# Altium schematic sheet 00_POWER.SchDoc(00_POWER)
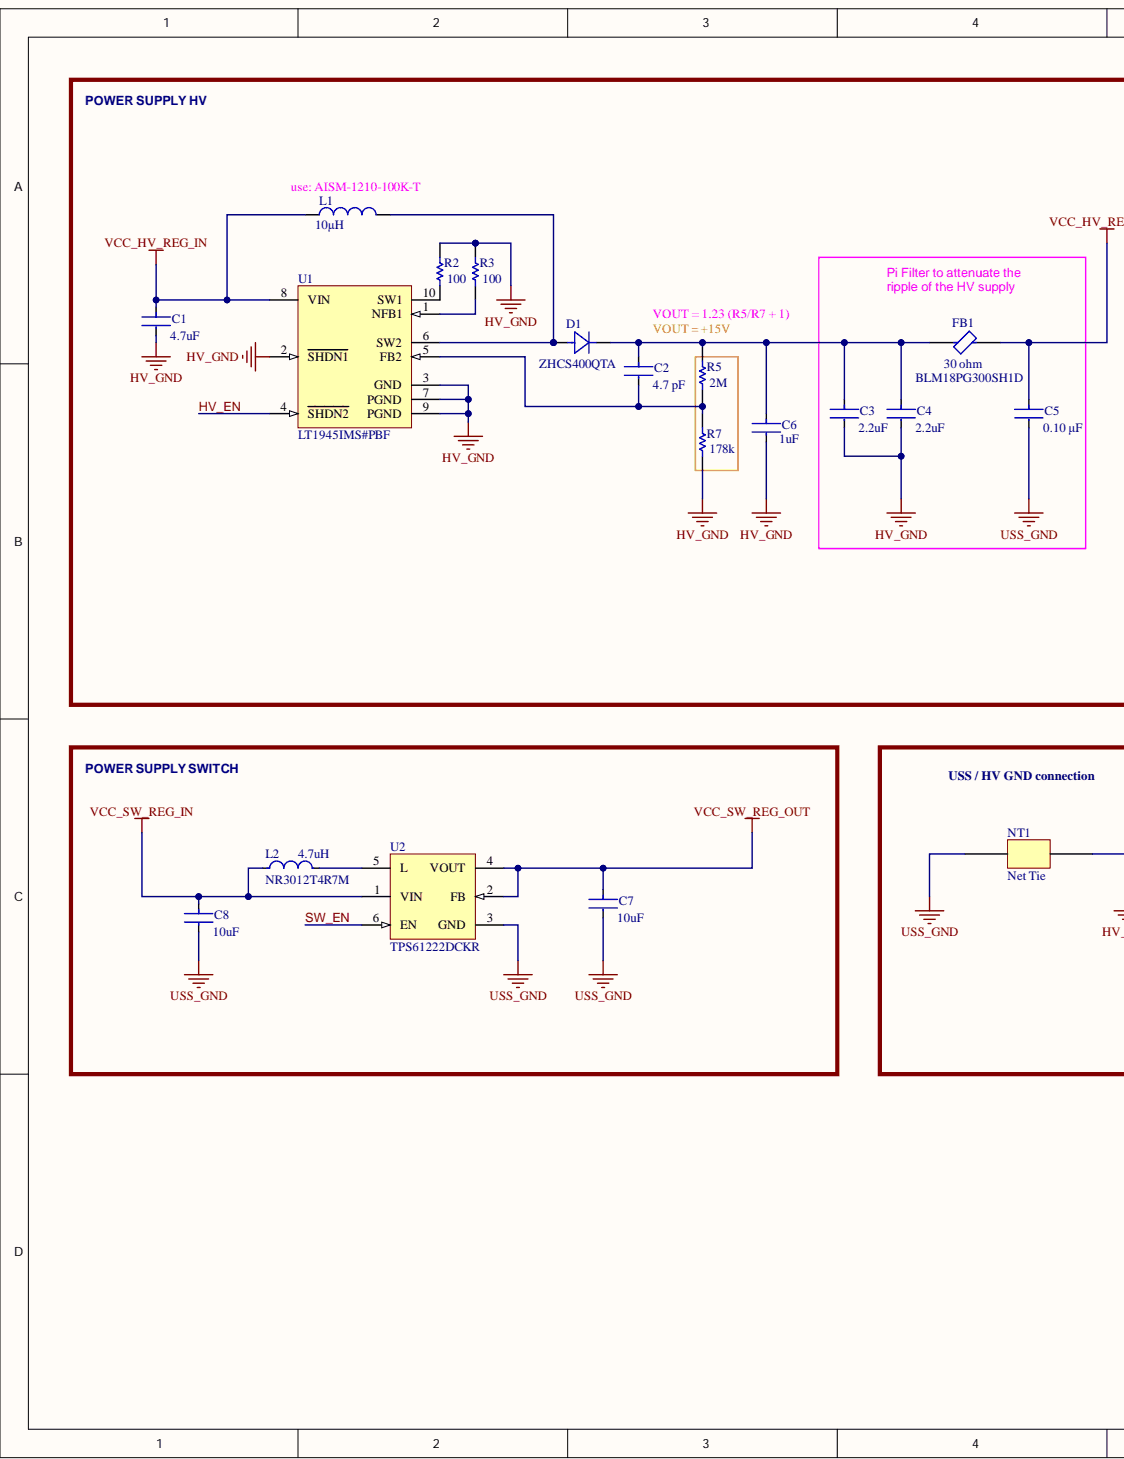
[diagram p0: 00_POWER.SchDoc, left]
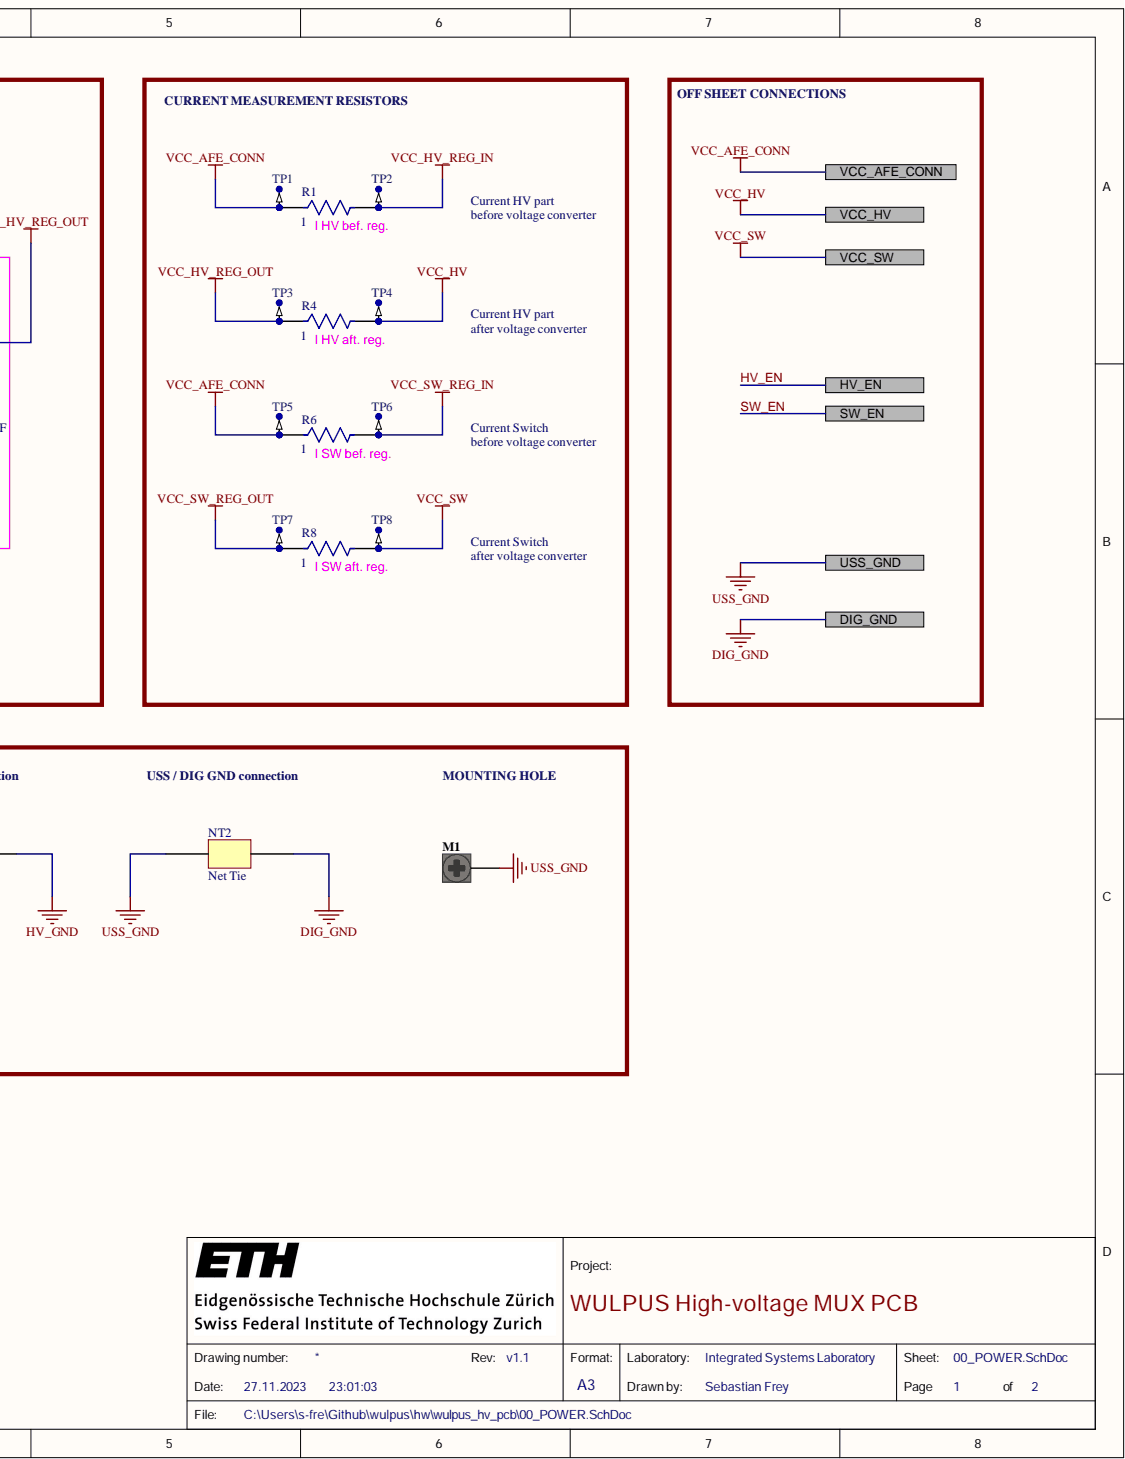
[diagram p1: 00_POWER.SchDoc, right]

## Parts
C1  Capacitance: 4.7uF  [FP-0603-L_1_6_0_15-W_0_81-MFG]  (hidden comment: 06036D475KAT2A)
C2  value 4.7 pF  Capacitance: 4.7 pF  [FP-C0402-BB-MFG]  (hidden comment: C0402C479C5GACTU)
C3  Capacitance: 2.2uF  [FP-GRM188-0_2-IPC_C]  (hidden comment: GRM188R61H225KE11D)
C4  Capacitance: 2.2uF  [FP-GRM188-0_2-IPC_C]  (hidden comment: GRM188R61H225KE11D)
C5  value 0.10 μF  Capacitance: 0.10 μF  [FP-C0603C-CF-MFG]  (hidden comment: C0603C104M5RACTU)
C6  Capacitance: 1uF  [FP-1206-L_3_2_0_15-W_1_6-IPC_B]  (hidden comment: GMK316BJ105KLHT)
C7  Capacitance: 10uF  [FP-GRM188-0_1-e0_2_0_55-IPC_A]  (hidden comment: GRM188R60J106ME47D)
C8  Capacitance: 10uF  [FP-GRM188-0_1-e0_2_0_55-IPC_A]  (hidden comment: GRM188R60J106ME47D)
D1  ZHCS400QTA  [DIODE_SOD323_DIO]
FB1  BLM18PG300SH1D  Impedance: 30 ohm  [FP-BLM18-0_15-t0_8-IPC_A]
L1  Inductance: 10µH  [TDK-B82422A1103K100_V]  (hidden comment: B82422A1103K100)
L2  NR3012T4R7M  Inductance: 4.7uH  [FP-NR3012-MFG]
M1  Mounting Hole for AFE PCB  [Hole M2]  (value not drawn)  (no BOM)
NT1  Net Tie  [Net_Tie_Top_Bottom]
NT2  Net Tie  [Net_Tie_Top_Bottom]
R1  CSR0402FK1R00  [FP-CSR0402-MFG]  (value not drawn)
R2  ERJ-2GEJ101X  [RESC1005X40X25NL05T05]  (value not drawn)
R3  ERJ-2GEJ101X  [RESC1005X40X25NL05T05]  (value not drawn)
R4  CSR0402FK1R00  [FP-CSR0402-MFG]  (value not drawn)
R5  Resistance: 2M  [RESC1005X40X25NL05T10]  (hidden comment: RC0402FR-072ML)
R6  CSR0402FK1R00  [FP-CSR0402-MFG]  (value not drawn)
R7  Resistance: 178k  [RESC1005X40X25ML05T05]  (hidden comment: ERJ-2RKF1783X)
R8  CSR0402FK1R00  [FP-CSR0402-MFG]  (value not drawn)
TP1  TP-SM-C-100  [TP-SM-C-100]  (value not drawn)  (no BOM)
TP2  TP-SM-C-100  [TP-SM-C-100]  (value not drawn)  (no BOM)
TP3  TP-SM-C-100  [TP-SM-C-100]  (value not drawn)  (no BOM)
TP4  TP-SM-C-100  [TP-SM-C-100]  (value not drawn)  (no BOM)
TP5  TP-SM-C-100  [TP-SM-C-100]  (value not drawn)  (no BOM)
TP6  TP-SM-C-100  [TP-SM-C-100]  (value not drawn)  (no BOM)
TP7  TP-SM-C-100  [TP-SM-C-100]  (value not drawn)  (no BOM)
TP8  TP-SM-C-100  [TP-SM-C-100]  (value not drawn)  (no BOM)
U1  LT1945IMS#PBF  [LT-MS-10_L]
U2  TPS61222DCKR  [DCK0006A_L]

## Ports
DIG_GND, HV_EN, SW_EN, USS_GND, VCC_AFE_CONN, VCC_HV, VCC_SW

## Nets
HV_GND: C1.2 C3.2 C4.2 C6.2 NT1.2 R2.2 R3.2 R7.1 U1.2(SHDN1) U1.3(GND) U1.7(PGND) U1.9(PGND)
VCC_HV_REG_IN: C1.1 L1.1 R1.2 TP2.1 U1.8(VIN)
VCC_HV_REG_OUT: C5.1 FB1.2 R4.1 TP3.1
VCC_SW_REG_IN: C8.1 L2.1 R6.2 TP6.1 U2.1(VIN)
VCC_SW_REG_OUT: C7.1 R8.1 TP7.1 U2.2(FB) U2.4(VOUT)
DIG_GND: NT2.2 ; port DIG_GND
HV_EN: U1.4(SHDN2) ; port HV_EN
SW_EN: U2.6(EN) ; port SW_EN
USS_GND: C5.2 C7.2 C8.2 M1.1 NT1.1 NT2.1 U2.3(GND) ; port USS_GND
VCC_AFE_CONN: R1.1 R6.1 TP1.1 TP5.1 ; port VCC_AFE_CONN
VCC_HV: R4.2 TP4.1 ; port VCC_HV
VCC_SW: R8.2 TP8.1 ; port VCC_SW
NetC2_1: C2.1 C3.1 C4.1 C6.1 D1.1 FB1.1 R5.2
NetC2_2: C2.2 R5.1 R7.2 U1.5(FB2)
NetD1_2: D1.2 L1.2 U1.6(SW2)
NetL2_2: L2.2 U2.5(L)
NetR2_1: R2.1 U1.10(SW1)
NetR3_1: R3.1 U1.1(NFB1)

## Library data of the parts
C1 = CMP-2006-04481-2: General Purpose Ceramic Capacitor, 0603, 4.7uF, 10%, X5R, 15%, 6.3V; Tolerance: 20%; Case Code (Imperial): 0603; Case Code (Metric): 1608; Case/Package: 0603; Component Kind: Standard; Construction: Flat; Contact Plating: Tin; Depth: 0.81mm; Dielectric: X5R; Dielectric Material: Ceramic; Height: 0.9mm; Lead Free: Lead Free; Length: 1.6mm; Library Name: Altium Content Vault; Max Operating Temperature: 85°C; Min Operating Temperature: -55°C; Mount: Surface Mount; Number of Pins: 2; Package Quantity: 1; Packaging: Tape and Reel; Radiation Hardening: No; REACH SVHC: No SVHC; RoHS Compliant: Yes; Series: -; Termination: SMD/SMT; Thickness: 0.035inch; Voltage: 6.3V; Voltage Rating: 6.3V; Voltage Rating (DC): 6.3V; Width: 0.032inch
C2 = CMP-2008-03310-2: CAP CER 4.7PF 50V NP0 0402; Manufacturer: Kemet; Material: Ceramic; Temperature Characteristic: C0G; Temperature Coefficient: 15%; Tolerance: 0.25 pF; Automotive: No; Category: Cap; Component Kind: Standard; ComponentLink2Description: Datasheet; ComponentLink2URL: https://content.kemet.com/datasheets/KEM_C1002_X7R_SMD.pdf; Device Class L1: Passive Components; Device Class L2: Capacitors; Device Class L3: Ceramic Capacitors; Digikey Description: CAP CER 4.7PF 50V NP0 0402; Height: 0.55mm; Ipc Land Pattern Name: CAPC10050X50; Lead Free: Yes; Library Name: Altium Content Vault; Manufacturer Part Number: C0402C479C5GACTU; Mouser Description: Multilayer Ceramic Capacitors MLCC - SMD/SMT 50volts 4.7pF C0G .25pF; Octopart: https://octopart.com/c0402c479c5gactu-kemet-9144199; Package: C0402-BB; RoHS: TRUE; Temperature: -55°C to +125°C; Temperature Range High: +125°C; Temperature Range Low: -55°C; Voltage Rating: 50 V
C3 C4 = CMP-06035-042125-1: Chip Multilayer Ceramic Capacitors for General Purpose, 0603, 2.2uF, X5R, 15%, 10%, 50V; Case Code (Imperial): 0603; Case Code (Metric): 1608; Case/Package: 0603; Component Kind: Standard; Dielectric: X5R; Height: 0.8mm; Length: 0.063inch; Library Name: Altium Content Vault; Material: Ceramic; Max Operating Temperature: 85°C; Min Operating Temperature: -55°C; Mount: Surface Mount; Packaging: Cut Tape; REACH SVHC: No SVHC; Thickness: 0.039inch; Tolerance: 10%; Voltage Rating: 50V; Voltage Rating (DC): 50V; Width: 0.031inch
C5 = CMP-2006-03068-2: CAP CER 0.1UF 50V 20% X7R 0603; Manufacturer: KEMET; Automotive Grade: Grade 1; Material: Ceramic; Temperature Coefficient: 30ppm/°C; Tolerance: 0.2; Automotive: Yes; Category: Cap; Component Kind: Standard; ComponentLink2Description: Datasheet; ComponentLink2URL: https://api.kemet.com/component-edge/download/datasheet/C0603C100J5GACAUTO.pdf; Device Class L1: Passive Components; Device Class L2: Capacitors; Device Class L3: Ceramic Capacitors; Digikey Description: CAP CER 0.1UF 50V 20% X7R 0603; Height: 0.87mm; Lead Free: Yes; Library Name: Altium Content Vault; Manufacturer Part Number: C0603C104M5RACTU; Mouser Description: Multilayer Ceramic Capacitors MLCC - SMD/SMT 50volts 0.1uF X7R 20%; Octopart: https://octopart.com/c0603c104m5ractu-kemet-11855687; Package: C0603C-CF; RoHS: TRUE; Temperature: -55°C to +125°C; Temperature Characteristic: X7R; Temperature Range High: +125°C; Temperature Range Low: -55°C; Voltage Rating: 50 V
C6 = CMP-14477-003256-2: None; Dielectric: X5R; Tolerance: 10%; Voltage Rating: 35V; Case Code (Imperial): 1206; Case Code (Metric): 3216; Case/Package: 1206; Component Kind: Standard; Halogen Free: Halogen Free; Height: 1.6mm; Lead Free: Lead Free; Length: 0.126inch; Library Name: Altium Content Vault; Max Operating Temperature: 85°C; Min Operating Temperature: -55°C; Mount: Surface Mount; Package Quantity: 2000; Packaging: Tape and Reel; Ratings: AEC-Q200; RoHS Compliant: Yes; Simulation: GMK316BJ105_LHT; Termination: SMD/SMT; Thickness: 0.071inch; Voltage Rating (DC): 35V; Width: 0.063inch
C7 C8 = CMP-2006-02792-2: Chip Multilayer Ceramic Capacitors for General Purpose, 0603, 10uF, X5R, 15%, 20%, 6.3V; Case Code (Imperial): 0603; Case Code (Metric): 1608; Case/Package: 0603; Component Kind: Standard; Depth: 0.8mm; Dielectric: X5R; Height: 0.8mm; Lead Free: Lead Free; Length: 1.6mm; Library Name: Altium Content Vault; Material: Ceramic; Max Operating Temperature: 85°C; Min Operating Temperature: -55°C; Mount: Surface Mount; Package Quantity: 4000; Packaging: Tape and Reel; Radiation Hardening: No; REACH SVHC: No SVHC; Termination: SMD/SMT; Thickness: 0.035inch; Tolerance: 20%; Voltage: 6.3V; Voltage Rating: 6.3V; Voltage Rating (DC): 6.3V; Width: 0.031inch
D1 = ZHCS400QTA: Diode Schottky 40V 1A Automotive 2-Pin SOD-323 T/R; Manufacturer: Diodes; Average Rectified Current: 1A; Category: Rectifier Diodes; Component Kind: Standard; ComponentLink1Description: http://www.mouser.com/ds/2/115/ZHCS400Q-959646.pdf?src-supplier=Mouser; ComponentLink1URL: http://www.mouser.com/ds/2/115/ZHCS400Q-959646.pdf?src-supplier=Mouser; Library Name: Schlib1.SchLib; Manufacturer Part Number: ZHCS400QTA; Max Reverse Voltage (DC): 40V; Mount: Surface Mount; Packaging: Tape and Reel; Pricing 1: 5=0.87300, 10=0.73400, 100=0.49400, 500=0.38800 (CHF); RoHS: Yes; RoHS Compliant: Yes; Stock 1: 385; Supplier 1: Farnell; Supplier Part Number 1: 3127490
FB1 = CMP-06046-000808-1: Chip Ferrite Bead, 0603, 30Ω @ 100MHz, 0.05Ω, , 1A; DC Resistance (DCR): 50mR; Number of Circuits: 1; Shielding: Unshielded; Tolerance: 25%; Case Code (Imperial): 0603; Case Code (Metric): 1608; Case/Package: 0603; Component Kind: Standard; Contact Plating: Tin; Height: 0.037inch; Length: 1.6mm; Library Name: Altium Content Vault; Max Current Rating: 1A; Max DC Current: 1A; Max Operating Temperature: 125°C; Min Operating Temperature: -55°C; Mount: Surface Mount; Number of Lines: 1; Package Quantity: 1; Packaging: Tape and Reel; Ratings: AEC-Q200; Termination: SMD/SMT; Test Frequency: 100MHz; Width: 0.031inch
L1 = CMP-2000-05912-1: Wirewound Inductor, 10 uF, +/- 10%, 180 mA, -55 to 125 degC, 2-Pin SMD, RoHS, Tape and Reel; Manufacturer: EPCOS-(TDK); Current Rating: 180mA; DC Resistance (DCR): 1.6OhmMax; Frequency - Self Resonant: 25MHz; Frequency - Test: 1MHz; Material - Core: Ferrite; Q @ Freq: 27@2.52MHz; Shielding: Unshielded; Category: Inductors,Coils,Chokes; Component Kind: Standard; Current - Saturation: -; Datasheet URL: https://en.tdk.eu/inf/30/db/ind_2008/b82422a_100.pdf; Datasheet Version: 10/2012; Family: FixedInductors; Height - Seated (Max): 0.083"(2.10mm); Library Name: Altium Content Vault; Manufacturer URL: http://www.tdk.com/; Mounting Technology: SurfaceMount; Mounting Type: SurfaceMount; Operating Temperature: -; Package / Case: 1210(3225Metric); Package Description: 2-Pin Surface Mount Device, Body 3.2 x 2.5 mm; Package Reference: B82422A1103K100; Packaging: CutTape(CT); Ratings: AEC-Q200; Series: SIMID; Size / Dimension: 0.126"Lx0.098"W(3.20mmx2.50mm); Tolerance: ±10%; Type: Wirewound
L2 = CMP-14484-001484-1: SMD Power Inductors 4.7uH ±20% 0.77A 0.13Ω; Core Material: Ferrite; Current Rating: 980mA; DC Resistance (DCR): 156mR; Max DC Current: 770mA; Self Resonant Frequency: 48MHz; Shielding: Shielded; Test Frequency: 100kHz; Case Code (Imperial): 1212; Case Code (Metric): 3030; Case/Package: 1212; Component Kind: Standard; DC Current: 980mA; Depth: 3.1mm; Halogen Free: Halogen Free; Height: 1.2mm; Height - Seated (Max): 0.047inch; Inductance Tolerance: 20%; Lead Free: Lead Free; Length: 0.118inch; Library Name: Altium Content Vault; Max Operating Temperature: 120°C; Military Standard: Not; Min Operating Temperature: -25°C; Mount: Surface Mount; Package Quantity: 2000; Packaging: Tape and Reel; Radiation Hardening: No; Resistor Type: Wirewound; RMS Current (Irms): 980mA; RoHS Compliant: Yes; Saturation Current: 770mA; Series: NR; Termination: SMD/SMT; Tolerance: 20%; Width: 0.118inch
M1 = CMP-00274-1: M2 Hole, 2.4mm drill, 5mm landing pads, 3mm pad in middle layers, no overlay; ClassName: Mounting Hole; Library Name: ETHZ Vault
NT1 NT2 = Net_Tie: Component Kind: Net Tie; Library Name: Schlib1.SchLib
R1 R4 R6 R8 = CMP-26527-000038-1: RES 1 OHM 1% 1/8W 0402; Max Power Dissipation: 125mW; Resistance: 1; Temperature Coefficient: 200ppm/°C; Case Code (Imperial): 0402; Case Code (Metric): 1005; Case/Package: 0402; Component Kind: Standard; Height: 0.017inch; Length: 0.039inch; Library Name: Altium Content Vault; Max Operating Temperature: 155°C; Min Operating Temperature: -55°C; Mount: Surface Mount; Number of Terminations: 2; Package Quantity: 1000; Packaging: Tape and Reel; Resistor Type: Thick Film; RoHS Compliant: Yes; Series: CSR; Tolerance: 1%; Width: 0.02inch
R2 R3 = CMP-2002-00431-1: Manufacturer: Panasonic; Resistance: 100; Component Kind: Standard; Library Name: Altium Content Vault; Part Number: ERJ-2GEJ101X
R5 = CMP-2002-07066-1: Manufacturer: Yageo / Phycomp; Component Kind: Standard; Library Name: Altium Content Vault; Part Number: RC0402FR-072ML
R7 = CMP-2002-00733-1: Manufacturer: Panasonic; Component Kind: Standard; Library Name: Altium Content Vault; Part Number: ERJ-2RKF1783X
TP1-TP8 = CMP-0484-00002-1: Test Point, Surface Mount, Circular, Pad Diameter 1 mm; Library Name: Altium Content Vault
U1 = CMP-0478-00154-1: Dual Micropower DC/DC Converter with Positive and Negative Outputs, 1.2 to 15 V Vin, 10-pin SOP (MS-10), -40 to 125 degC, Pb-Free; Manufacturer: Linear Technology; Number of Outputs: 2; Vin Max(V): 15; Vin Min(V): 1.2; Component Kind: Standard; ComponentLink1Description: Manufacturer URL; ComponentLink1URL: http://www.linear.com/; ComponentLink2Description: Datasheet; ComponentLink2URL: http://cds.linear.com/docs/en/datasheet/1945fa.pdf; ComponentLink3Description: Package Specification; ComponentLink3URL: http://cds.linear.com/docs/en/packaging/05081661_F_MS.pdf; DatasheetVersion: Rev. A; Library Name: Altium Content Vault; Mounting Technology: Surface Mount; PackageDescription: 10-Pin Plastic MSOP, Body 3 x 3 mm, Pitch 0.5 mm; PackageReference: MS-10; PackageVersion: Rev. E, 06/2010; PartNumber: LT1945IMS#PBF; RoHS: TRUE
U2 = CMP-0347-00055-2: Boost Voltage Converter with 0.7 to 5.5 V Input and 5 V Output, -40 to 85 degC, 6-Pin SC70 (DCK), Green (RoHS & no Sb/Br); Manufacturer: Texas Instruments; Regulated Outputs(#): 1; SubFamily: Step-Up Regulator; Switching Frequency(Max)(kHz): 2000; Vin(Max)(V): 5.5; Vin(Min)(V): 0.7; Vout(Max)(V): 5; Code_JEDEC: MO-203-AB; Component Kind: Standard; ComponentLink1Description: Datasheet; ComponentLink1URL: http://www.ti.com/general/docs/lit/getliterature.tsp?genericPartNumber=TPS61222&fileType=pdf; ComponentLink2Description: Package Specification; ComponentLink2URL: http://www.ti.com/litv/pdf/mpds114c; DatasheetVersion: SLVS776; Eco-Plan: Green (RoHS & no Sb/Br); Library Name: Altium Content Vault; Mounting Technology: Surface Mount; PackageDescription: 6-Pin Plastic Small-Outline Package, 2 x 1.3 mm Body, 0.65 mm Pitch, 2.1 mm Lead Span; PackageReference: DCK0006A; PackageVersion: revG, Jan-2007; PartNumber: TPS61222DCKR; Topology: Boost; Vout(Min)(V): 5
